# Altium schematic sheet Schematic.SchDoc
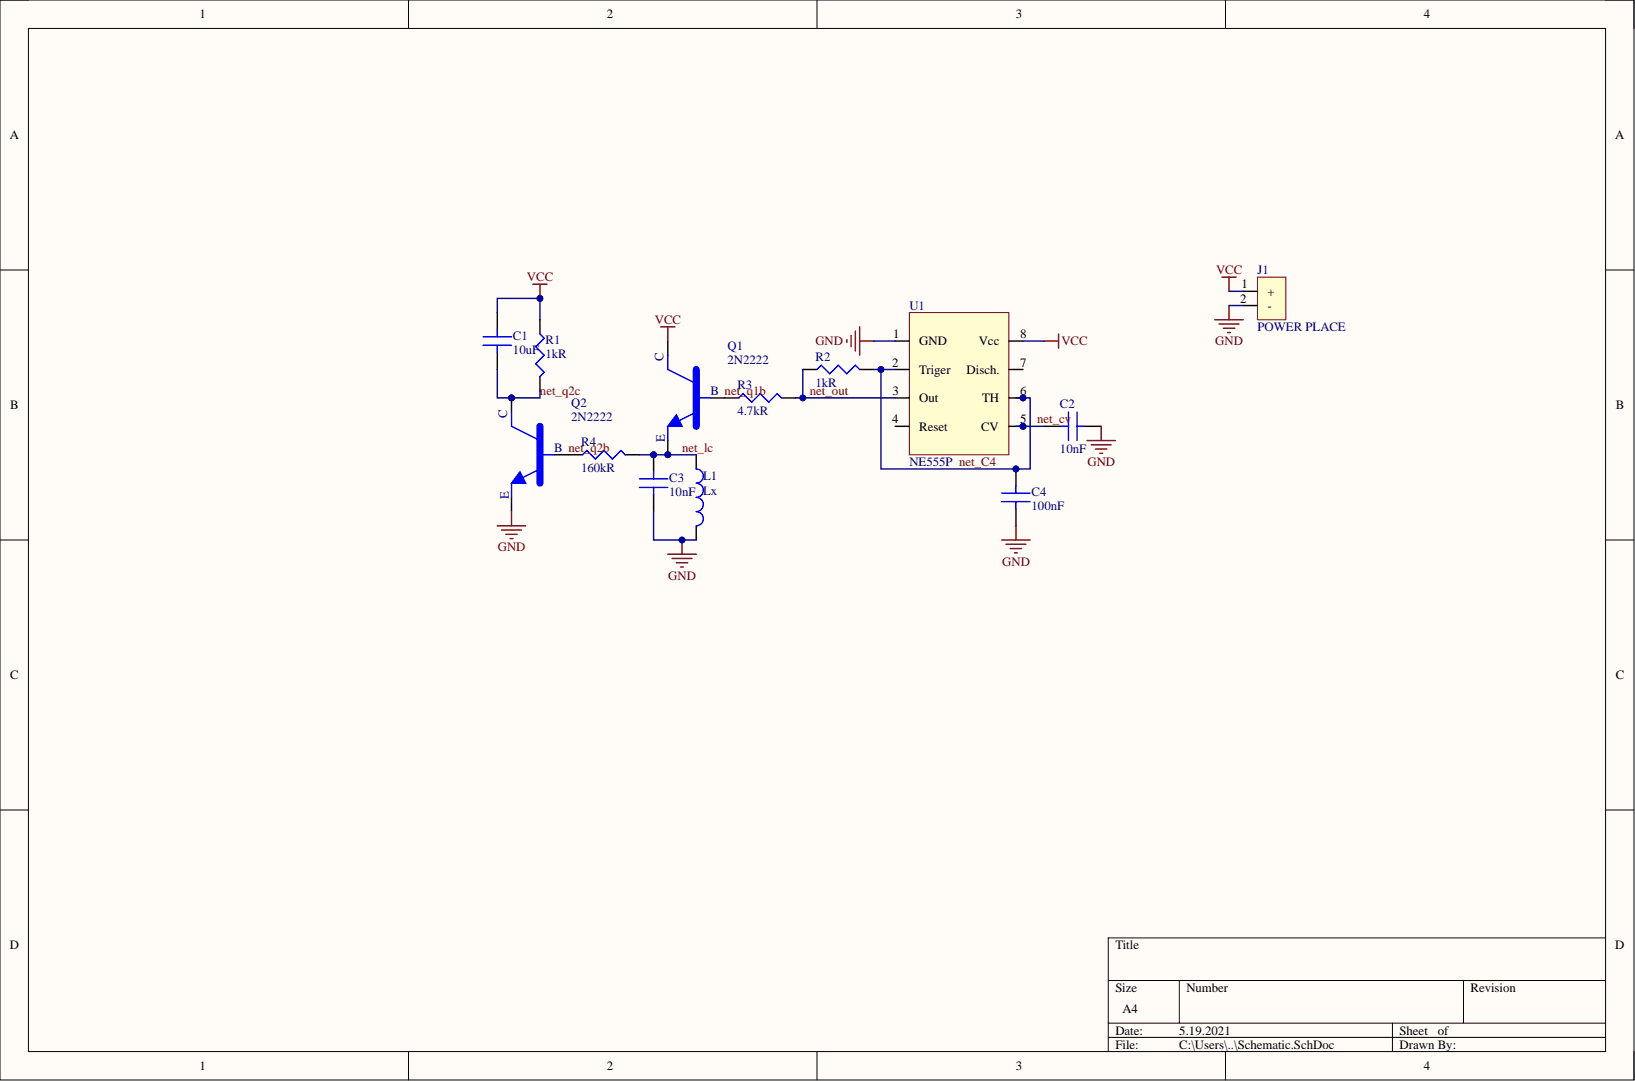
[diagram p0: Schematic.SchDoc]

## Parts
C1  10uF  [C0805]
C2  10nF  [C0805]
C3  10nF  [C0805]
C4  100nF  [C0805]
J1  POWER PLACE  [poweplc]
L1  Lx  [LX]
Q1  2N2222  [2N2222SMD]
Q2  2N2222  [2N2222SMD]
R1  1kR  [R0805]
R2  1kR  [R0805]
R3  4.7kR  [R0805]
R4  160kR  [R0805]
U1  NE555P  [NE555]

## Nets
GND: C2.2 C3.1 C4.2 J1.2 L1.2 Q2.2 U1.1(GND)
VCC: C1.2 J1.1 Q1.3 R1.2 U1.8(Vcc)
net_C4: C4.1 R2.1 U1.2(Triger) U1.6(TH)
net_cv: C2.1 U1.5(CV)
net_lc: C3.2 L1.1 Q1.2 R4.1
net_out: R2.2 R3.1 U1.3(Out)
net_q1b: Q1.1 R3.2
net_q2b: Q2.1 R4.2
net_q2c: C1.1 Q2.3 R1.1

Not connected: U1 4(Reset) 7(Disch.)

## Library data of the parts
C1 = Cap_0805_10u: Cap Ceramic 10uF 16V X5R 10% SMD 0805 85C Cardboard T/R; Manufacturer: Samsung; Manufacturer Part Number: CL21A106KOCLRNC; Capacitance: 10uF; Case Code (Imperial): 0805; Case Code (Metric): 2012; Case/Package: 0805; Category: Ceramic Capacitors; Component Kind: Standard; ComponentLink1Description: https://datasheet.ciiva.com/25797/cl21a106koclrnc-25797024.pdf?src-supplier=Digi-Key; ComponentLink1URL: https://datasheet.ciiva.com/25797/cl21a106koclrnc-25797024.pdf?src-supplier=Digi-Key; ComponentLink2Description: https://datasheet.ciiva.com/26838/getdatasheetpartid-357378-26838585.pdf?src-supplier=Verical; ComponentLink2URL: https://datasheet.ciiva.com/26838/getdatasheetpartid-357378-26838585.pdf?src-supplier=Verical; Construction: Flat; Depth: 1.25mm; Dielectric: X5R; Height: 0.85mm; Lead Free: Lead Free; Length: 2mm; Library Name: Schlib.SchLib; Max Operating Temperature: 85°C; Min Operating Temperature: -55°C; Mount: Surface Mount; Package Quantity: 1; Packaging: Tape and Reel; Pricing 1: 1=0,17600, 50=0,07680, 100=0,06630, 500=0,04710, 1500=0,03730 (USD); Radiation Hardening: No; RoHS: Yes; RoHS Compliant: Yes; Series: CL; Stock 1: 131352; Supplier 1: Future Electronics; Supplier Part Number 1: 8103687; Thickness: 0.037inch; Tolerance: 10%; Voltage Rating: 16V; Width: 0.049inch
C2 C3 = Cap_0805_10n: Cap Ceramic 0.01uF 25V X7R 10% Pad SMD 0805 125°C T/R; Manufacturer: Samsung; Manufacturer Part Number: CL21B103KAANNNC; Capacitance: 10nF; Case Code (Imperial): 0805; Case Code (Metric): 2012; Case/Package: 0805; Category: Ceramic Capacitors; Component Kind: Standard; ComponentLink1Description: https://datasheet.ciiva.com/25781/cl21b103kaannnc-25781200.pdf?src-supplier=Digi-Key; ComponentLink1URL: https://datasheet.ciiva.com/25781/cl21b103kaannnc-25781200.pdf?src-supplier=Digi-Key; ComponentLink2Description: https://datasheet.ciiva.com/16199/cl05b104kq5nnnc-16199720.pdf?src-supplier=Future+Electronics; ComponentLink2URL: https://datasheet.ciiva.com/16199/cl05b104kq5nnnc-16199720.pdf?src-supplier=Future+Electronics; Construction: Flat; Depth: 1.25mm; Dielectric: X7R; Height: 0.65mm; Length: 2mm; Library Name: Schlib.SchLib; Max Operating Temperature: 125°C; Min Operating Temperature: -55°C; Mount: Surface Mount; Package Quantity: 1; Packaging: Tape and Reel; Pricing 1: 1=0,10000, 10=0,04700, 50=0,02560, 100=0,02080, 500=0,01490, 1000=0,01170 (USD); Radiation Hardening: No; RoHS: Yes; RoHS Compliant: Yes; Series: CL; Stock 1: 1191; Supplier 1: Digi-Key; Supplier Part Number 1: 1276-2434-1-ND; Thickness: 0.03inch; Tolerance: 10%; Voltage Rating: 25V; Width: 0.049inch
C4 = Cap_0805_100n: WALSIN - 0805B104K160CT - Keramikvielschichtkondensator, SMD, 0.1 µF, 16 V, 0805 [Metrisch 2012], ± 10%, X7R; Manufacturer: Walsin Technologies; Voltage Rating: 16V; Capacitance: 100nF; Case Code (Imperial): 0805; Case Code (Metric): 2012; Case/Package: Ceramic; Category: Ceramic Capacitors; Component Kind: Standard; ComponentLink1Description: https://datasheet.ciiva.com/26864/getdatasheetpartid-556603-26864634.pdf?src-supplier=Verical; ComponentLink1URL: https://datasheet.ciiva.com/26864/getdatasheetpartid-556603-26864634.pdf?src-supplier=Verical; Depth: 1.25mm; Dielectric: X7R; Length: 2mm; Library Name: Schlib.SchLib; Manufacturer Part Number: 0805B104K160CT; Max Operating Temperature: 125°C; Min Operating Temperature: -55°C; Packaging: Tape and Reel; Pricing 1: 1=0,12000, 10=0,08600, 50=0,04900, 100=0,04050, 500=0,02842, 1000=0,02352 (USD); Radiation Hardening: No; REACH SVHC: Unknown; RoHS: Yes; RoHS Compliant: Yes; Stock 1: 12085; Supplier 1: Digi-Key; Supplier Part Number 1: 1292-1565-1-ND; Tolerance: 10%; Voltage Rating (DC): 16V
Q1 Q2 = SMMBT2222ALT1G: ON SEMICONDUCTOR         SMMBT2222ALT1G             Bipolar (BJT) Single Transistor, NPN, 40 V, 300 MHz, 225 mW, 600 mA, 40 hFE                          New; Collector Base Voltage (VCBO): 75V; Collector Emitter Breakdown Voltage: 40V; Collector Emitter Saturation Voltage: 1V; Emitter Base Voltage (VEBO): 6V; Frequency: 300MHz; hFE Min: 100; Max Collector Current: 600mA; Max Frequency: 100MHz; Max Power Dissipation: 225mW; Number of Elements: 1; Power Dissipation: 300mW; Case/Package: SOT-23-3; Category: BJTs; Collector Emitter Voltage (VCEO): 40V; Collector-emitter Voltage-Max: 1V; Component Kind: Standard; ComponentLink1Description: https://datasheet.ciiva.com/980/mmbt2222lt1-d-980645.pdf?src-supplier=Digi-Key; ComponentLink1URL: https://datasheet.ciiva.com/980/mmbt2222lt1-d-980645.pdf?src-supplier=Digi-Key; ComponentLink2Description: https://datasheet.ciiva.com/16285/mmbt2222lt1-d-80103-16285882.pdf?src-supplier=Mouser; ComponentLink2URL: https://datasheet.ciiva.com/16285/mmbt2222lt1-d-80103-16285882.pdf?src-supplier=Mouser; ComponentLink3Description: https://datasheet.ciiva.com/16488/mmbt2222lt1-d-16488314.pdf?src-supplier=Future+Electronics; ComponentLink3URL: https://datasheet.ciiva.com/16488/mmbt2222lt1-d-16488314.pdf?src-supplier=Future+Electronics; Contact Plating: Tin,Matte; Element Configuration: Single; Gain Bandwidth Product: 300MHz; Height: 1.11mm; Lead Free: Lead Free; Length: 3.04mm; Library Name: Schlib.SchLib; Manufacturer: ON Semiconductor; Manufacturer Part Number: SMMBT2222ALT1G; Max Breakdown Voltage: 40V; Max Operating Temperature: 150°C; Min Operating Temperature: -55°C; Number of Pins: 3; Package Quantity: 3000; Packaging: Tape and Reel; Polarity: NPN; Pricing 1: 100=0,07100, 200=0,05900, 500=0,05200, 1000=0,04500, 2000=0,04400 (USD); Radiation Hardening: No; REACH SVHC: No SVHC; RoHS: Yes; RoHS Compliant: Yes; Series: -; Stock 1: 6700; Supplier 1: RSComponents; Supplier Part Number 1: 7815222; Transition Frequency: 300MHz; Width: 2.64mm
R1 R2 = Res_0805_1k: KOA SPEER ELECTRONICS         RK73H2ATTD1001F             SMD Chip Resistor, Thick Film, 1 kohm, 150 V, 0805 [2012 Metric], 125 mW,  1%, RK73H Series; Power Rating: 125mW; Temperature Coefficient: 100ppm/°C; Voltage Rating: 150V; Case Code (Imperial): 0805; Case Code (Metric): 2012; Case/Package: 0805; Category: Chip SMD Resistors; Component Kind: Standard; ComponentLink1Description: https://datasheet.ciiva.com/5557/rk73h-5557241.pdf?src-supplier=Mouser; ComponentLink1URL: https://datasheet.ciiva.com/5557/rk73h-5557241.pdf?src-supplier=Mouser; ComponentLink2Description: https://datasheet.ciiva.com/7706/549017-7706107.pdf?src-supplier=Element14; ComponentLink2URL: https://datasheet.ciiva.com/7706/549017-7706107.pdf?src-supplier=Element14; Construction: Rectangular; Depth: 1.25mm; Height: 0.5mm; Lead Free: Lead Free; Length: 2mm; Library Name: Schlib.SchLib; Manufacturer: KOA Speer; Manufacturer Part Number: RK73H2ATTD1001F; Max Operating Temperature: 155°C; Military Standard: Not; Min Operating Temperature: -55°C; Number of Pins: 2; Package Quantity: 5000; Packaging: Tape and Reel; Pricing 1: 1=0,10000, 10=0,03500, 100=0,01420, 1000=0,00640, 2500=0,00556 (USD); REACH SVHC: Unknown; Resistance: 1kR; Resistance Tolerance: 1%; Resistor Type: Thick Film; RoHS: Yes; RoHS Compliant: Yes; Series: RK73H; Stock 1: 32; Supplier 1: Digi-Key; Supplier Part Number 1: 2019-RK73H2ATTD1001FCT-ND; Termination: SMD/SMT; Tolerance: 1%; Voltage Rating (DC): 150V; Width: 1.25mm
R3 = Res_0805_4.7k: Res Thick Film 0805 4.7K Ohm 1% 0.25W(1/4W) ±100ppm/C Pad SMD Automotive T/R; Manufacturer: KOA Speer; Manufacturer Part Number: RK73H2ATTD4701F; Power Rating: 125mW; Temperature Coefficient: 100ppm/°C; Voltage Rating: 150V; Case Code (Imperial): 0805; Case Code (Metric): 2012; Case/Package: 0805; Category: Chip SMD Resistors; Component Kind: Standard; ComponentLink1Description: https://datasheet.ciiva.com/7706/549017-7706124.pdf?src-supplier=Element14; ComponentLink1URL: https://datasheet.ciiva.com/7706/549017-7706124.pdf?src-supplier=Element14; Height: 0.5mm; Lead Free: Lead Free; Length: 2mm; Library Name: Schlib.SchLib; Max Operating Temperature: 155°C; Min Operating Temperature: -55°C; Number of Pins: 2; Package Quantity: 5000; Packaging: Tape and Reel; Pricing 1: 10=0,05500, 25=0,03500, 50=0,02500, 100=0,01900, 250=0,01400 (USD); REACH SVHC: Unknown; Resistance: 4.7kR; Resistance Tolerance: 1%; Resistor Type: Thick Film; RoHS: Yes; RoHS Compliant: Yes; Series: RK73H; Stock 1: 68360; Supplier 1: Newark; Supplier Part Number 1: 85AH1468; Termination: SMD/SMT; Tolerance: 1%; Voltage Rating (DC): 150V; Width: 1.25mm
R4 = Res_0805_160k: Thick Film Resistors - SMD 0805 160Kohms 1% Tol; Manufacturer: Panasonic; Manufacturer Part Number: ERJ-6ENF1603V; Max Power Dissipation: 125mW; Temperature Coefficient: 100ppm/°C; Voltage Rating: 150V; Case Code (Imperial): 0805; Case Code (Metric): 2012; Case/Package: 0805; Category: Chip SMD Resistors; Component Kind: Standard; ComponentLink1Description: https://datasheet.ciiva.com/22674/1678782-22674517.pdf?src-supplier=Element14; ComponentLink1URL: https://datasheet.ciiva.com/22674/1678782-22674517.pdf?src-supplier=Element14; ComponentLink2Description: https://datasheet.ciiva.com/46436/1678782-46436470.pdf?src-supplier=Element14; ComponentLink2URL: https://datasheet.ciiva.com/46436/1678782-46436470.pdf?src-supplier=Element14; ComponentLink3Description: https://datasheet.ciiva.com/9185/aoa0000ce2-9185402.pdf?src-supplier=Avnet; ComponentLink3URL: https://datasheet.ciiva.com/9185/aoa0000ce2-9185402.pdf?src-supplier=Avnet; ComponentLink4Description: https://datasheet.ciiva.com/22739/1678782-22739739.pdf?src-supplier=Element14; ComponentLink4URL: https://datasheet.ciiva.com/22739/1678782-22739739.pdf?src-supplier=Element14; ComponentLink5Description: https://datasheet.ciiva.com/24060/aoa0000ce2-24060654.pdf?src-supplier=Mouser; ComponentLink5URL: https://datasheet.ciiva.com/24060/aoa0000ce2-24060654.pdf?src-supplier=Mouser; ComponentLink6Description: https://datasheet.ciiva.com/46436/1678782-46436469.pdf?src-supplier=Element14; ComponentLink6URL: https://datasheet.ciiva.com/46436/1678782-46436469.pdf?src-supplier=Element14; ComponentLink7Description: https://datasheet.ciiva.com/17207/aoa0000ce2-17207337.pdf?src-supplier=Avnet+Europe; ComponentLink7URL: https://datasheet.ciiva.com/17207/aoa0000ce2-17207337.pdf?src-supplier=Avnet+Europe; Construction: Rectangular; Contact Plating: Tin; Depth: 1.25mm; Features: Automotive AEC-Q200; Height: 0.028inch; Length: 2mm; Library Name: Schlib.SchLib; Max Operating Temperature: 155°C; Military Standard: Not; Min Operating Temperature: -55°C; Mount: Surface Mount; Number of Terminations: 2; Package Quantity: 1; Packaging: Tape and Reel; Power Rating: 125mW; Pricing 1: 1=0,10000, 10=0,07500, 100=0,02880, 1000=0,01265, 2500=0,01092 (USD); Radiation Hardening: No; REACH SVHC: No SVHC; Resistance: 160kR; Resistance Tolerance: 1%; Resistor Type: Thick Film; RoHS: Yes; RoHS Compliant: Yes; Series: ERJ; Stock 1: 3366; Supplier 1: Digi-Key; Supplier Part Number 1: P160KCCT-ND; Termination: SMD/SMT; Tolerance: 1%; Width: 0.049inch
U1 = NE555P: Standard Timer Single 8-Pin PDIP Tube; Manufacturer: Texas Instruments; Current Rating: 10mA; Frequency: 500kHz; High Level Output Current: -200mA; Low Level Output Current: 200mA; Max Supply Voltage: 16V; Min Supply Voltage: 4.5V; Nominal Supply Current: 2mA; Number of Timers/Counters: 1; Operating Supply Voltage: 5V; Quiescent Current: 15mA; Case/Package: PDIP; Category: Clock and Timing; Component Kind: Standard; ComponentLink1Description: https://datasheet.ciiva.com/1139/sa555-1139904.pdf?src-supplier=Element14; ComponentLink1URL: https://datasheet.ciiva.com/1139/sa555-1139904.pdf?src-supplier=Element14; ComponentLink2Description: https://datasheet.ciiva.com/19457/904085-19457361.pdf?src-supplier=Jameco; ComponentLink2URL: https://datasheet.ciiva.com/19457/904085-19457361.pdf?src-supplier=Jameco; ComponentLink3Description: https://datasheet.ciiva.com/26325/152184-da-01-en-ne555p-timer-tid-26325915.pdf?src-supplier=Conrad; ComponentLink3URL: https://datasheet.ciiva.com/26325/152184-da-01-en-ne555p-timer-tid-26325915.pdf?src-supplier=Conrad; ComponentLink4Description: https://datasheet.ciiva.com/1147/1764032-1147750.pdf?src-supplier=Newark; ComponentLink4URL: https://datasheet.ciiva.com/1147/1764032-1147750.pdf?src-supplier=Newark; ComponentLink5Description: https://datasheet.ciiva.com/14094/ne555-series-eng-tds-14094950.pdf?src-supplier=Distrelec; ComponentLink5URL: https://datasheet.ciiva.com/14094/ne555-series-eng-tds-14094950.pdf?src-supplier=Distrelec; ComponentLink6Description: https://datasheet.ciiva.com/14274/ne555-14274456.pdf?src-supplier=Avnet; ComponentLink6URL: https://datasheet.ciiva.com/14274/ne555-14274456.pdf?src-supplier=Avnet; ComponentLink7Description: https://datasheet.ciiva.com/15152/getdatasheetpartid-248510-15152620.pdf?src-supplier=Verical; ComponentLink7URL: https://datasheet.ciiva.com/15152/getdatasheetpartid-248510-15152620.pdf?src-supplier=Verical; ComponentLink8Description: https://datasheet.ciiva.com/1233/ne555-1233454.pdf?src-supplier=Digi-Key; ComponentLink8URL: https://datasheet.ciiva.com/1233/ne555-1233454.pdf?src-supplier=Digi-Key; ComponentLink9Description: https://datasheet.ciiva.com/26228/ne555-26228892.pdf?src-supplier=Avnet+Europe; ComponentLink9URL: https://datasheet.ciiva.com/26228/ne555-26228892.pdf?src-supplier=Avnet+Europe; Contact Plating: Gold; Height: 4.57mm; Lead Free: Lead Free; Length: 9.81mm; Library Name: Schlib.SchLib; Manufacturer Part Number: NE555P; Max Operating Temperature: 70°C; Min Operating Temperature: 0°C; Mount: Through Hole; Number of Pins: 8; Operating Supply Current: 10mA; Package Quantity: 50; Packaging: Rail/Tube; Pricing 1: 1=0,37000, 10=0,29900, 25=0,27360, 100=0,20350, 250=0,18444, 500=0,15264, 1000=0,11448, 2500=0,10494, 5000=0,10020 (USD); Radiation Hardening: No; REACH SVHC: No SVHC; RoHS: Yes; RoHS Compliant: Yes; Stock 1: 3671; Supplier 1: Digi-Key; Supplier Part Number 1: 296-NE555P-ND; Weight: 0.015535oz; Width: 6.35mm
J1 = POWER PLACE: Component Kind: Standard; Library Name: Schlib.SchLib
L1 = Lx: Component Kind: Standard; Library Name: Schlib.SchLib
